# Altium schematic sheet wisp-6-main.SchDoc(wisp-6-main)
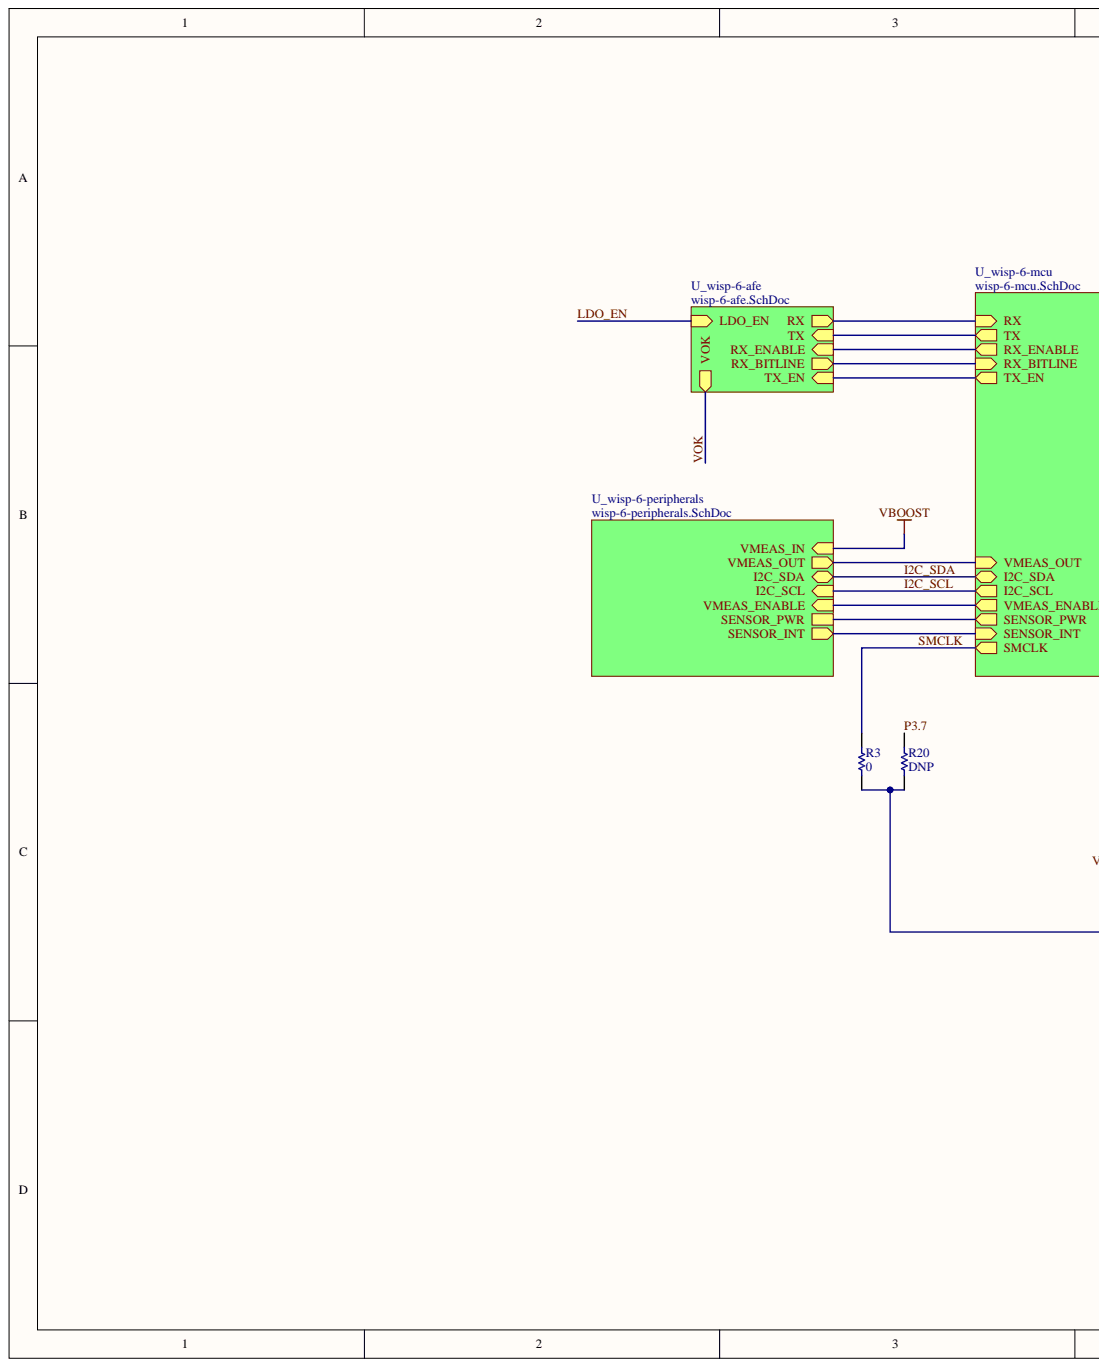
[diagram p0: wisp-6-main.SchDoc, left]
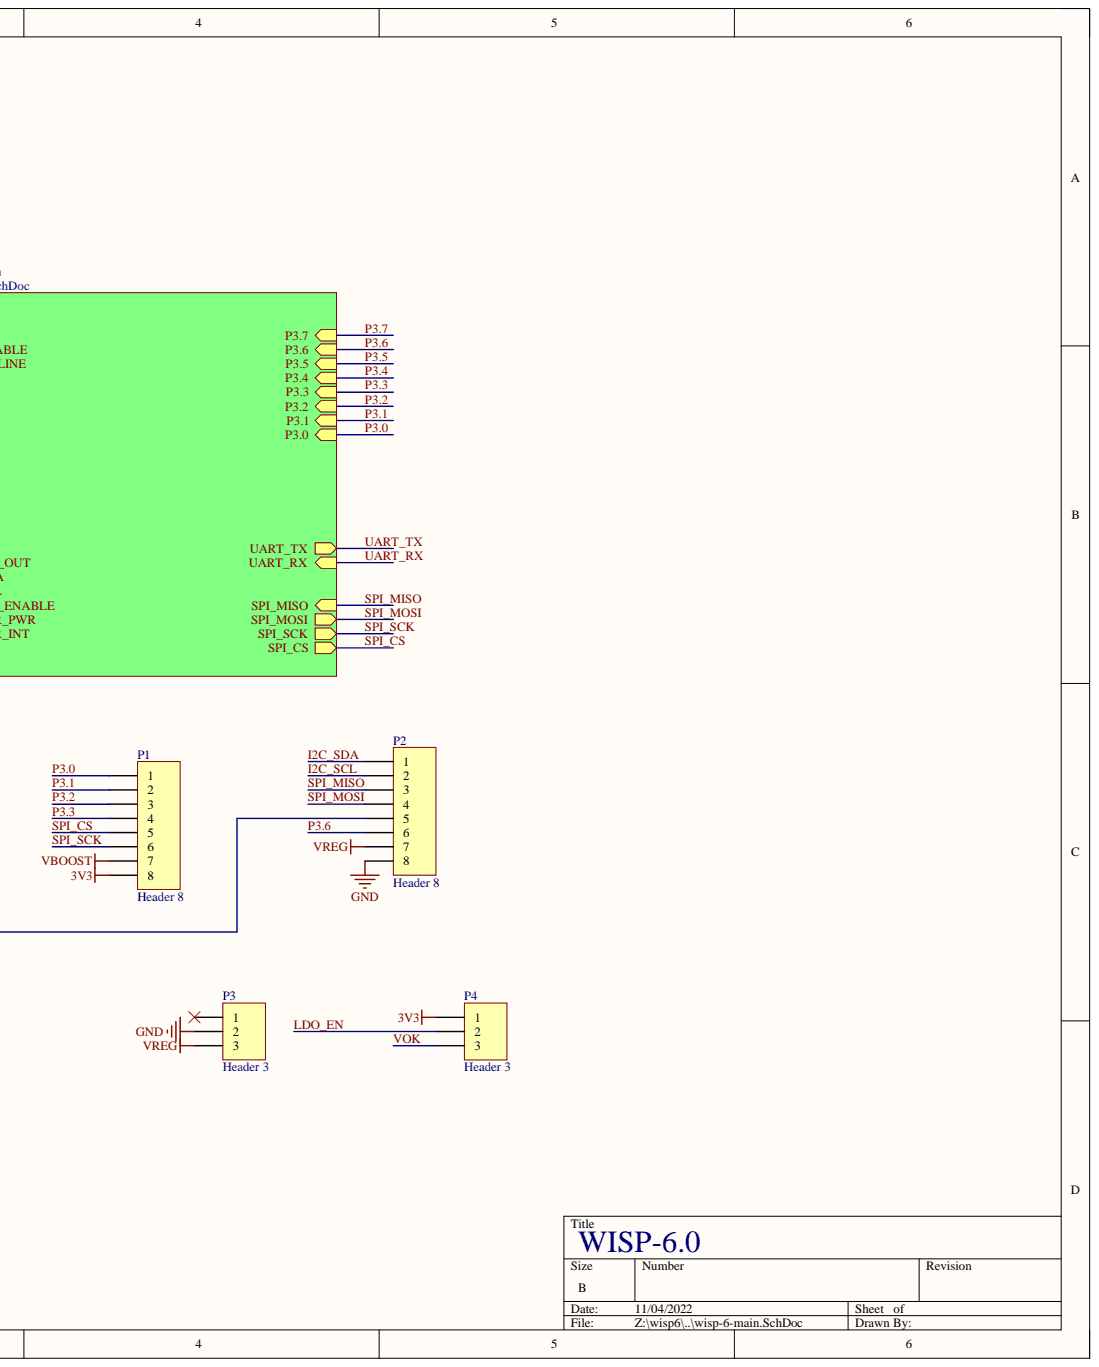
[diagram p1: wisp-6-main.SchDoc, right]

## Parts
P1  Header 8  [HDR1X8]
P2  Header 8  [HDR1X8]
P3  Header 3  [HDR1X3]
P4  Header 3  [HDR1X3]
R3  value 0  [0402]  (hidden comment: Res)
R20  value DNP  [0402]  (hidden comment: Res)

## Nets
3V3: P1.8 P4.1
GND: P2.8 P3.2
VBOOST: P1.7
VREG: P2.7 P3.3
I2C_SCL: P2.2
I2C_SDA: P2.1
LDO_EN: P4.2
P3.0: P1.1
P3.1: P1.2
P3.2: P1.3
P3.3: P1.4
P3.6: P2.6
P3.7: R20.2
SMCLK: R3.2
SPI_CS: P1.5
SPI_MISO: P2.3
SPI_MOSI: P2.4
SPI_SCK: P1.6
VOK: P4.3
NetP2_5: P2.5 R3.1 R20.1
NetP3_1: P3.1
P3.4:
P3.5:
RX:
RX_BITLINE:
RX_ENABLE:
SENSOR_INT:
SENSOR_PWR:
TX:
TX_EN:
UART_RX:
UART_TX:
VMEAS_ENABLE:
VMEAS_OUT:

## Library data of the parts
P1 P2 = Header 8: Header, 8-Pin; Component Kind: Standard; LatestRevisionDate: 17-Jul-2002; LatestRevisionNote: Re-released for DXP Platform.; Library Name: Miscellaneous Connectors.IntLib; Signal Integrity: Connector; Publisher: Altium Limited
P3 P4 = Header 3: Header, 3-Pin; Component Kind: Standard; LatestRevisionDate: 17-Jul-2002; LatestRevisionNote: Re-released for DXP Platform.; Library Name: Miscellaneous Connectors.IntLib; Signal Integrity: Connector; Publisher: Altium Limited
R3 R20 = Res: Chip Resistor (Surface Mount); Manufacturer: Generic Chip Res (TBD); Component Kind: Standard; Library Name: WISP_AD10.SchLib
